# Altium schematic sheet DiagnosticsController.SchDoc
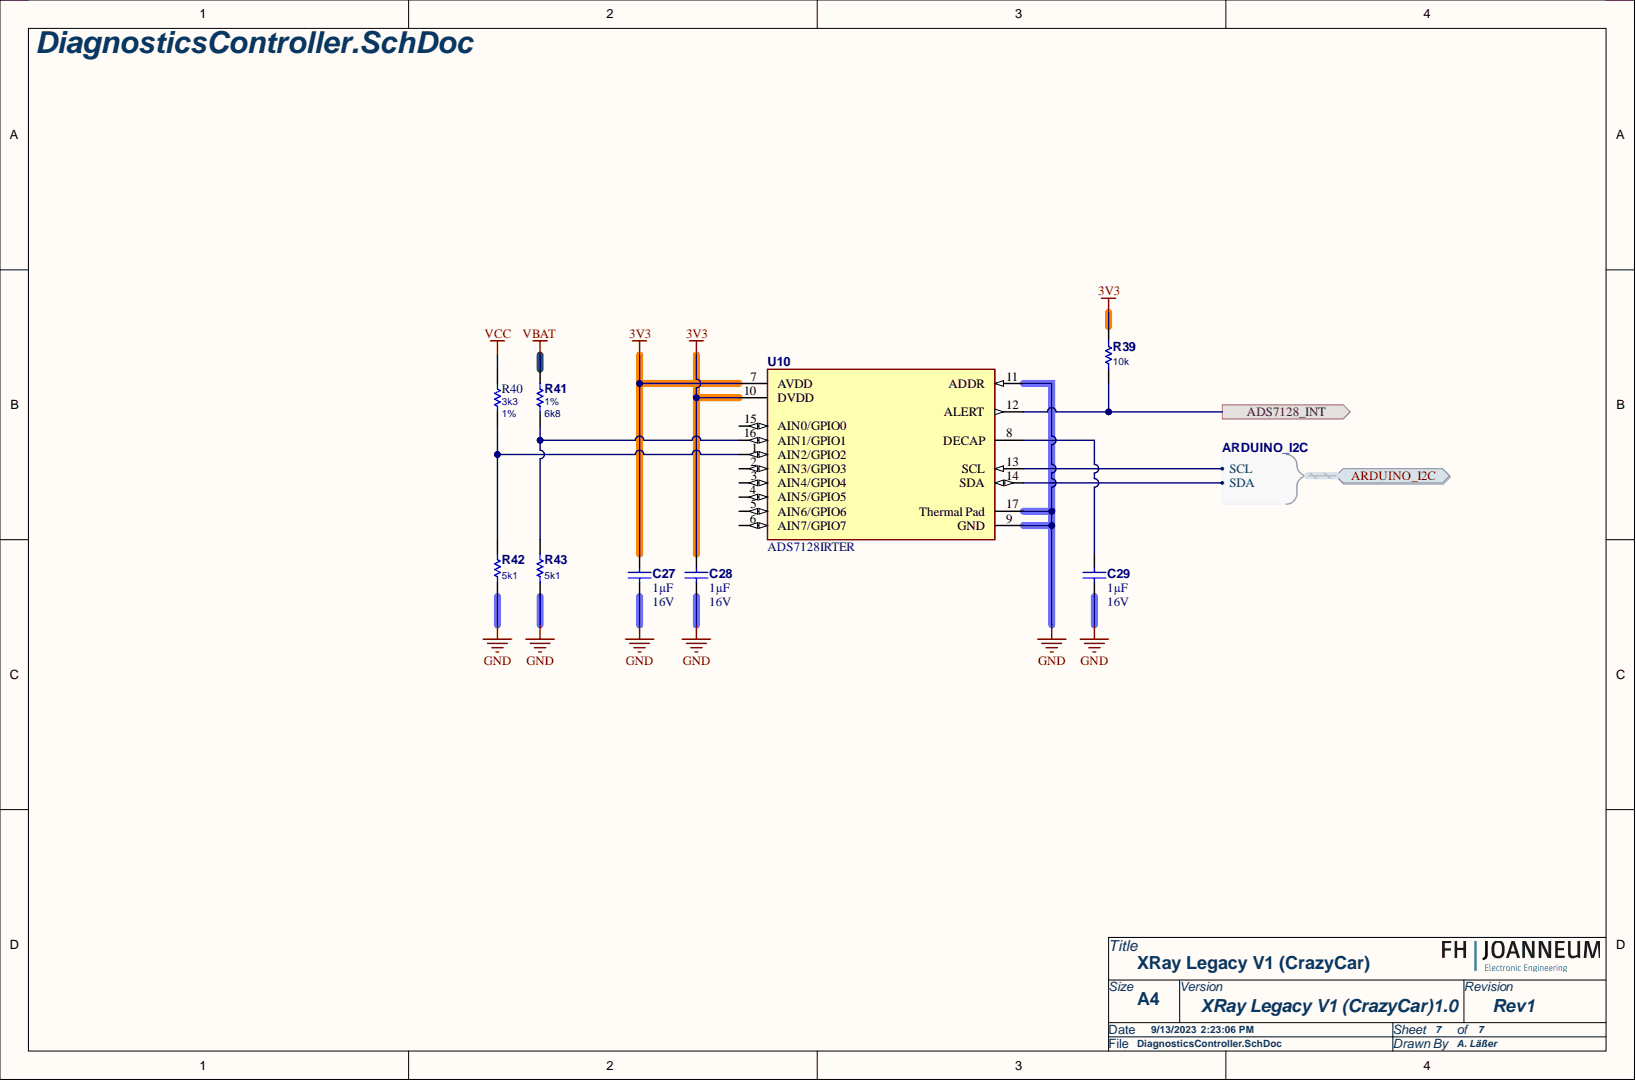
[diagram p0: DiagnosticsController.SchDoc]

## Parts
C27  1µF  Rated Voltage (DC): 16V  [WCAP-CSGP_0805_L2W1.25H1.25]
C28  1µF  Rated Voltage (DC): 16V  [WCAP-CSGP_0805_L2W1.25H1.25]
C29  1µF  Rated Voltage (DC): 16V  [WCAP-CSGP_0805_L2W1.25H1.25]
R39  Resistance: 10k  [RESC2013X60X35ML10T20]  (hidden comment: RC0805FR-0710KL)
R40  Resistance: 3k3  Tolerance: 1%  [RESC2013X60X35ML10T20]  (hidden comment: RC0805FR-073K3L)
R41  Resistance: 6k8  Tolerance: 1%  [RESC2013X60X35ML10T20]  (hidden comment: RC0805FR-136K8L)
R42  Resistance: 5k1  [RESC2013X60X35ML10T20]  (hidden comment: RC0805FR-0710KL)
R43  Resistance: 5k1  [RESC2013X60X35ML10T20]  (hidden comment: RC0805FR-0710KL)
U10  ADS7128IRTER  [FP-RTE0016C-MFG]

## Ports
ADS7128_INT, ARDUINO_I2C, ARDUINO_I2C.SCL, ARDUINO_I2C.SDA

## Nets
3V3: C27.1 C28.1 R39.1 U10.7(AVDD) U10.10(DVDD)
GND: C27.2 C28.2 C29.2 R42.2 R43.2 U10.9(GND) U10.11(ADDR) U10.17(Thermal_Pad)
VBAT: R41.2
VCC: R40.1
ADS7128_INT: R39.2 U10.12(ALERT) ; port ADS7128_INT
NetC29_1: C29.1 U10.8(DECAP)
NetR40_2: R40.2 R42.1 U10.1(AIN2/GPIO2)
NetR41_1: R41.1 R43.1 U10.16(AIN1/GPIO1)
NetU10_13: U10.13(SCL) ; port ARDUINO_I2C
NetU10_14: U10.14(SDA) ; port ARDUINO_I2C

Not connected: U10 2(AIN3/GPIO3) 3(AIN4/GPIO4) 4(AIN5/GPIO5) 5(AIN6/GPIO6) 6(AIN7/GPIO7) 15(AIN0/GPIO0)

## Library data of the parts
C27-C29 = 885012207051: WCAP-CSGP Multilayer Ceramic Chip Capacitor, General Purpose, size 0805, X7R, 1µF, 16VDC; Manufacturer: Wurth Elektronik; Capacitance Tolerance: +/-10%; Case/Size Code: 0805; Dissipation Factor: 5%; Insulation Resistance: 0.5GΩ; Technical Reference: X7R0805105K016DFCT10000; Capacitance: 1µF; Category: Multilayer Ceramic Chip Capacitors; Ceramic Type: X7R; Component Kind: Standard; ComponentLink1Description: Website Link; ComponentLink1URL: https://www.we-online.com/redexpert/article/885012207051?ad; ComponentLink2Description: Datasheet Link; ComponentLink2URL: https://www.we-online.com/redexpert/spec/885012207051?ad; Height: 1.25mm; Length: 2mm; Library Name: Altium_WCAP-CSGP (rev22e).IntLib; Manufacturer Part Number: 885012207051; Match Code: WCAP-CSGP; Mount: Surface Mount; Operating Temperature Max: 125°C; Operating Temperature Min: -55°C; Packaging: Tape and Reel; Width: 1.25mm
R39 R42 R43 = CMP-1659-00013-4: Chip Resistor, 10 KOhm, +/- 1%, 0.125 W, -55 to 155 degC, 0805 (2012 Metric), RoHS, Tape and Reel; Manufacturer: Yageo; Additional Feature: LASER TRIMMABLE; Package Height: 0.5mm; Package Length: 2mm; Package Width: 1.25mm; Rated Power Dissipation (P): 125mW; Rated Temperature: 70°C; Temperature Coefficient: 100ppm/°C; Voltage Rating: 150 V; Working Voltage: 150V; Category: Chip SMD Resistors; Component Kind: Standard; ComponentLink1Description: Manufacturer URL; ComponentLink1URL: http://www.yageo.com/; ComponentLink2Description: Datasheet; ComponentLink2URL: http://www.yageo.com/documents/recent/PYu-RC_Group_51_RoHS_L_04.pdf; ComponentLink3Description: https://datasheet.ciiva.com:443/pdfs/VipMasterIC/IC/YAGO/YAGO-S-A0008145938/YAGO-S-A0008145938-1.pdf?src-supplier=IHS+Markit; ComponentLink3URL: https://datasheet.ciiva.com:443/pdfs/VipMasterIC/IC/YAGO/YAGO-S-A0008145938/YAGO-S-A0008145938-1.pdf?src-supplier=IHS+Markit; ComponentLink4Description: https://datasheet.ciiva.com/11808/0900766b8106beab-11808151.pdf?src-supplier=RSComponents; ComponentLink4URL: https://datasheet.ciiva.com/11808/0900766b8106beab-11808151.pdf?src-supplier=RSComponents; ComponentLink5Description: https://datasheet.ciiva.com/46447/444164-46447563.pdf?src-supplier=Element14; ComponentLink5URL: https://datasheet.ciiva.com/46447/444164-46447563.pdf?src-supplier=Element14; ComponentLink6Description: https://datasheet.ciiva.com/2456/pyu-r-int-thick-7-2456973.pdf?src-supplier=Digi-Key; ComponentLink6URL: https://datasheet.ciiva.com/2456/pyu-r-int-thick-7-2456973.pdf?src-supplier=Digi-Key; ComponentLink7Description: https://datasheet.ciiva.com/2456/pyu-r-int-thick-7-2456974.pdf?src-supplier=Digi-Key; ComponentLink7URL: https://datasheet.ciiva.com/2456/pyu-r-int-thick-7-2456974.pdf?src-supplier=Digi-Key; ComponentLink8Description: https://datasheet.ciiva.com/2456/pyu-r-int-thick-7-2456972.pdf?src-supplier=Digi-Key; ComponentLink8URL: https://datasheet.ciiva.com/2456/pyu-r-int-thick-7-2456972.pdf?src-supplier=Digi-Key; ComponentLink9Description: https://datasheet.ciiva.com/12669/rc0805-12669688.pdf?src-supplier=Mouser; ComponentLink9URL: https://datasheet.ciiva.com/12669/rc0805-12669688.pdf?src-supplier=Mouser; ComponentLink10Description: https://datasheet.ciiva.com/16747/rc0805-16747087.pdf?src-supplier=Future+Electronics; ComponentLink10URL: https://datasheet.ciiva.com/16747/rc0805-16747087.pdf?src-supplier=Future+Electronics; Construction: Chip; DatasheetVersion: Rev. 4, 02/2016; Height: 0.5 mm; JESD-609 Code: e3; Length: 2 mm; Library Name: Altium Content Vault; Manufacturer Part Number: RC0805FR-0710KL; Max Operating Temperature: 155 degC; Min Operating Temperature: -55 degC; Mount: Surface Mount; Mounting Technology: Surface Mount; Number of Pins: 2; Package Quantity: 5000; Package Shape: Rectangular; Package Style: SMTMeter; PackageDescription: 2-Pin Surface Mounted Device, Body 2 x 1.25 mm; PackageReference: 0805; Packaging: Tape and Reel; Pins: 2; Pricing 1: 5000=0.00700 (USD); REACH SVHC: No SVHC; Resistor Type: FIXED RESISTOR; RoHS: Yes; RoHS Compliant: Yes; RoHSCompliant: true; Size Code: 0805; Stock 1: 435000; Supplier 1: RSComponents; Supplier Part Number 1: 6185163; Technology: METAL GLAZE/THICK FILM; Terminal Finish: Nickel; Termination: Wraparound; Tolerance: 1%
R40 = CMP-1013-00567-2: Chip Resistor, 3.3 KOhm, +/- 1%, 0.125 W, -55 to 155 degC, 0805 (2012 Metric), RoHS, Tape and Reel; Manufacturer: Yageo; Additional Feature: LASER TRIMMABLE; Max Power Dissipation: 125mW; Package Height: 0.5mm; Package Length: 2mm; Package Width: 1.25mm; Rated Temperature: 70°C; Temperature Coefficient: 100ppm/degreesC; Voltage Rating: 150V; Case Code (Imperial): 0805; Case Code (Metric): 2012; Case/Package: 0805; Category: Chip SMD Resistors; Component Kind: Standard; ComponentLink1Description: https://datasheet.ciiva.com:443/pdfs/VipMasterIC/IC/YAGO/YAGO-S-A0008145938/YAGO-S-A0008145938-1.pdf?src-supplier=IHS+Markit; ComponentLink1URL: https://datasheet.ciiva.com:443/pdfs/VipMasterIC/IC/YAGO/YAGO-S-A0008145938/YAGO-S-A0008145938-1.pdf?src-supplier=IHS+Markit; ComponentLink2Description: https://datasheet.ciiva.com/2457/pyu-r-int-thick-7-2457994.pdf?src-supplier=Digi-Key; ComponentLink2URL: https://datasheet.ciiva.com/2457/pyu-r-int-thick-7-2457994.pdf?src-supplier=Digi-Key; ComponentLink3Description: https://datasheet.ciiva.com/2457/pyu-r-int-thick-7-2457992.pdf?src-supplier=Digi-Key; ComponentLink3URL: https://datasheet.ciiva.com/2457/pyu-r-int-thick-7-2457992.pdf?src-supplier=Digi-Key; ComponentLink4Description: https://datasheet.ciiva.com/12577/rc0805-12577341.pdf?src-supplier=Mouser; ComponentLink4URL: https://datasheet.ciiva.com/12577/rc0805-12577341.pdf?src-supplier=Mouser; ComponentLink5Description: https://datasheet.ciiva.com/7941/444164-7941782.pdf?src-supplier=Element14; ComponentLink5URL: https://datasheet.ciiva.com/7941/444164-7941782.pdf?src-supplier=Element14; ComponentLink6Description: https://datasheet.ciiva.com/7941/444164-7941783.pdf?src-supplier=Element14; ComponentLink6URL: https://datasheet.ciiva.com/7941/444164-7941783.pdf?src-supplier=Element14; ComponentLink7Description: https://datasheet.ciiva.com/16747/rc0805-16747115.pdf?src-supplier=Future+Electronics; ComponentLink7URL: https://datasheet.ciiva.com/16747/rc0805-16747115.pdf?src-supplier=Future+Electronics; ComponentLink8Description: https://datasheet.ciiva.com/46447/444164-46447579.pdf?src-supplier=Element14; ComponentLink8URL: https://datasheet.ciiva.com/46447/444164-46447579.pdf?src-supplier=Element14; ComponentLink9Description: https://datasheet.ciiva.com/2457/pyu-r-int-thick-7-2457993.pdf?src-supplier=Digi-Key; ComponentLink9URL: https://datasheet.ciiva.com/2457/pyu-r-int-thick-7-2457993.pdf?src-supplier=Digi-Key; Composition: ThickFilm; Construction: Chip; Datasheet URL: http://www.yageo.com/NewPortal/yageodocoutput?fileName=/pdf/R-Chip/PYu-RC_Group_51_RoHS_L_5.pdf; Datasheet Version: Rev. 5, 10/2016; Depth: 1.25mm; Height: 0.5mm; JESD-609 Code: e3; Lead Free: LeadFree; Length: 2mm; Library Name: Altium Content Vault; Manufacturer Part Number: RC0805FR-073K3L; Manufacturer URL: http://www.yageo.com/; Max Operating Temperature: 155degC; Min Operating Temperature: -55degC; Mount: Surface Mount; Number of Pins: 2; Number of Terminations: 2; Package Description: 2-Pin Surface Mounted Device, Body 2 x 1.25 mm; Package Quantity: 1; Package Reference: 0805; Package Shape: Rectangular; Package Style: SMTMeter; Packaging: TapeandReel; Power Rating: 125mW; Pricing 1: 5000=0.0027, 25000=0.0025, 125000=0.0024, 500000=0.0023, 1250000=0.0021 (USD); Rated Power Dissipation (P): 125mW; REACH SVHC: NoSVHC; Reach SVHC Compliant: Yes; Resistor Type: FIXED RESISTOR; RoHS: Yes; RoHS Compliant: Yes; RoHSCompliant: true; Size Code: 0805; Stock 1: 4335000; Supplier 1: Future Electronics; Supplier Part Number 1: 1297803; Technology: METAL GLAZE/THICK FILM; Terminal Finish: Nickel; Termination: SMD/SMT; Weight: 0.000194oz; Width: 0.049inch; Working Voltage: 150V
R41 = CMP-2001-04567-1: Fixed Resistor, Metal Glaze/thick Film, 0.125W, 6800ohm, 150V, 1% +/-Tol, 100ppm/Cel, Surface Mount, 0805; Manufacturer: Yageo; Additional Feature: LASER TRIMMABLE; Package Height: 0.5mm; Package Length: 2mm; Package Width: 1.25mm; Rated Power Dissipation (P): 125mW; Rated Temperature: 70°C; Temperature Coefficient: 100ppm/°C; Category: Chip SMD Resistors; Component Kind: Standard; ComponentLink1Description: https://datasheet.ciiva.com:443/pdfs/VipMasterIC/IC/YAGO/YAGO-S-A0008145938/YAGO-S-A0008145938-1.pdf?src-supplier=IHS+Markit; ComponentLink1URL: https://datasheet.ciiva.com:443/pdfs/VipMasterIC/IC/YAGO/YAGO-S-A0008145938/YAGO-S-A0008145938-1.pdf?src-supplier=IHS+Markit; ComponentLink2Description: http://www.mouser.com/ds/2/447/Yageo_08112016_RC0805-968729.pdf?src-supplier=Mouser; ComponentLink2URL: http://www.mouser.com/ds/2/447/Yageo_08112016_RC0805-968729.pdf?src-supplier=Mouser; ComponentLink3Description: http://www.yageo.com/documents/recent/PYu-RC_Group_51_RoHS_L_2.pdf?src-supplier=Avnet; ComponentLink3URL: http://www.yageo.com/documents/recent/PYu-RC_Group_51_RoHS_L_2.pdf?src-supplier=Avnet; Construction: Chip; JESD-609 Code: e3; Library Name: Altium Content Vault; Manufacturer Part Number: RC0805FR-136K8L; Max Operating Temperature: 155°C; Min Operating Temperature: -55°C; Mount: Surface Mount; Number of Pins: 2; Package Shape: Rectangular; Package Style: SMTMeter; Packaging: Tape and Reel; Part Number: RC0805FR-136K8L; Pricing 1: 1=0.1, 10=0.024, 50=0.0152, 100=0.0127, 500=0.00866, 1000=0.00748, 5000=0.00548, 10000=0.00486 (USD); Reach SVHC Compliant: Yes; Resistor Type: FIXED RESISTOR; RoHS: Yes; RoHS Compliant: Yes; Size Code: 0805; Stock 1: 31895; Supplier 1: Digi-Key; Supplier Part Number 1: 13-RC0805FR-136K8LCT-ND; Technology: METAL GLAZE/THICK FILM; Terminal Finish: Nickel; Termination: Wraparound; Working Voltage: 150V
U10 = CMP-04860-000052-1: IC ADC 12BIT SAR 16WQFN; Component Kind: Standard; Library Name: Altium Content Vault
